# Altium schematic sheet P770010E05-Xx-SH-5_Connector.SchDoc(P770010E05-Xx-SH-5_Connector)
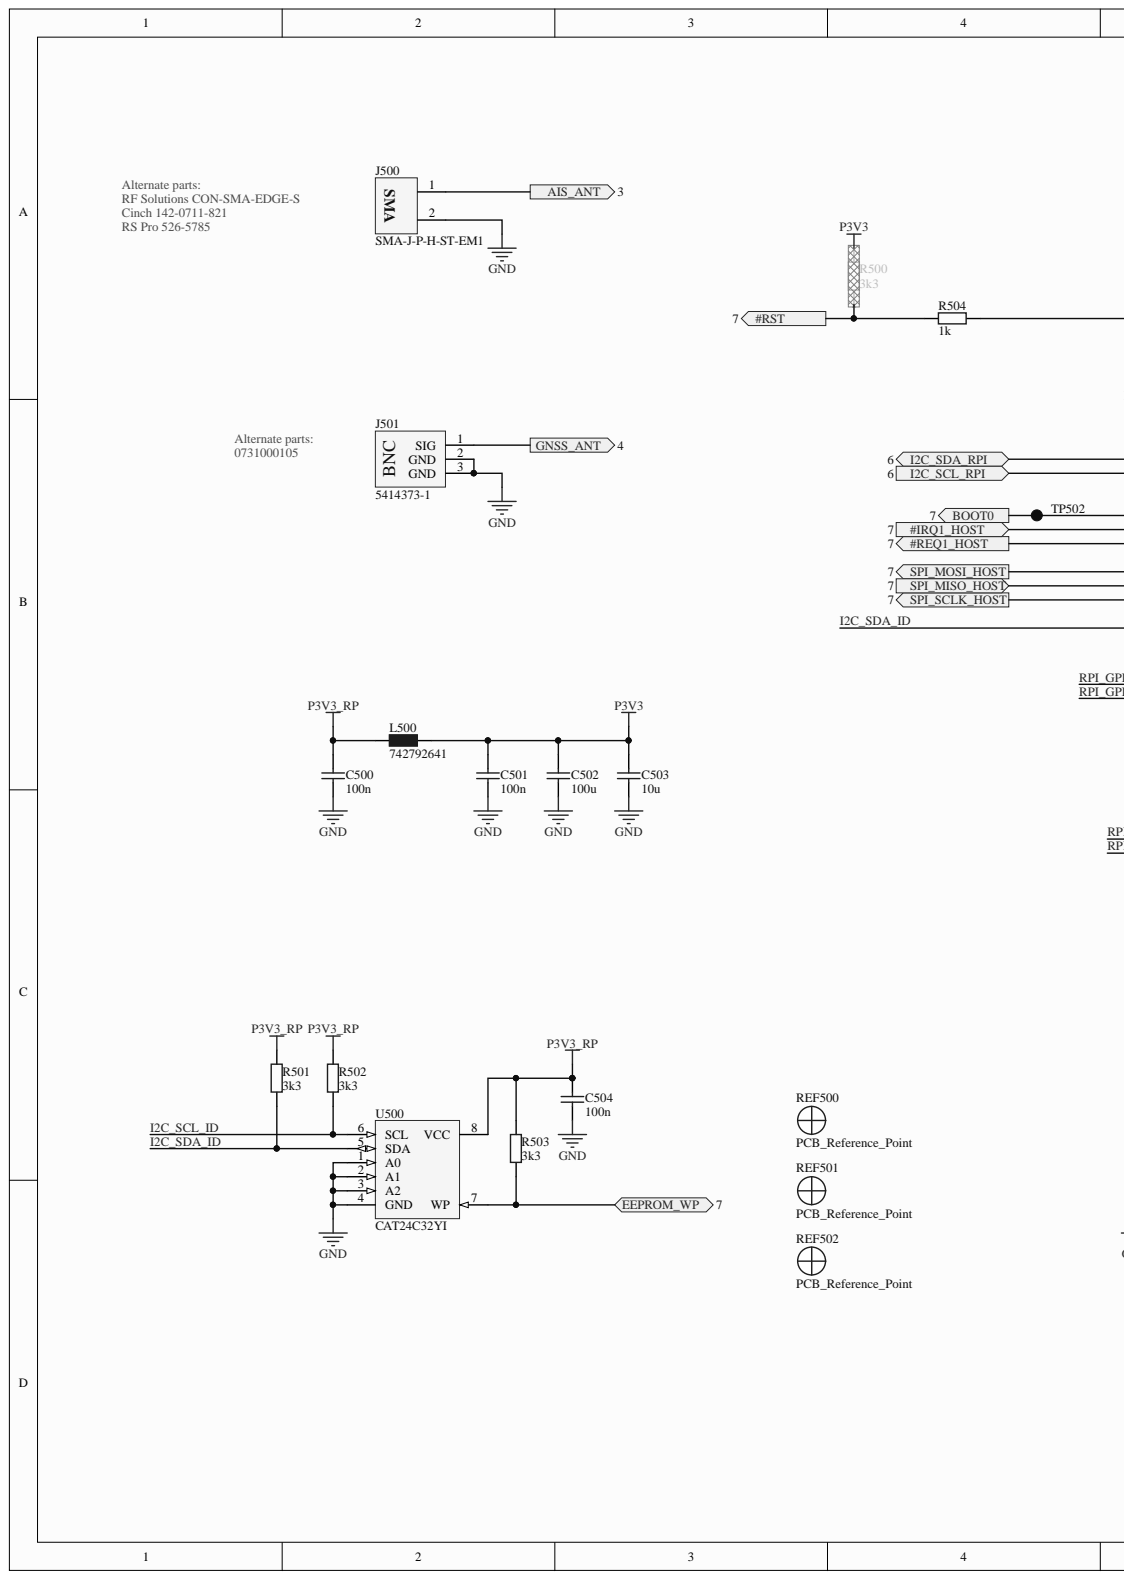
[diagram p0: P770010E05-Xx-SH-5_Connector.SchDoc, left]
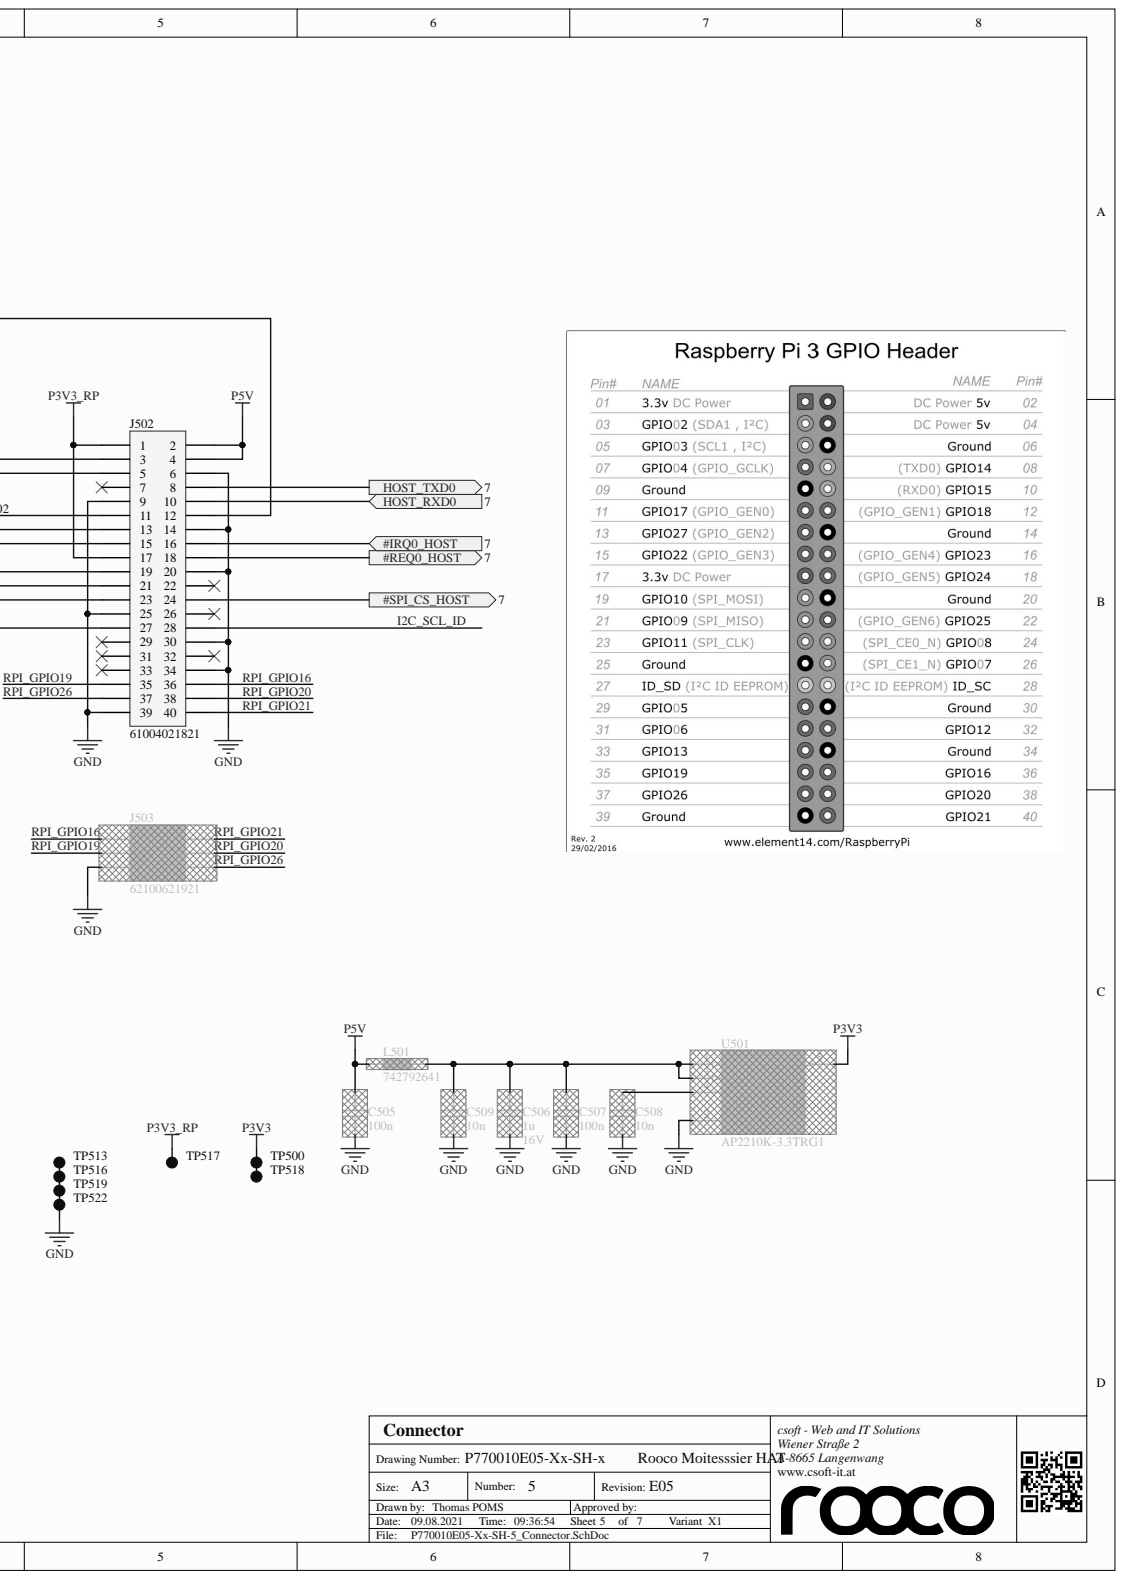
[diagram p1: P770010E05-Xx-SH-5_Connector.SchDoc, right]

## Parts
C500  100n  [C0402]
C501  100n  [C0402]
C502  100u  [C1206]
C503  10u  [C0805]
C504  100n  [C0402]
C505  100n  [C0402]
C506  1u  VoltageTypical: 16V  [C0603]
C507  100n  [C0402]
C508  10n  [C0402]
C509  10n  [C0402]
J500  SMA-J-P-H-ST-EM1  [SMA-J-P-X-ST-EM1_RECE-20279-001E-01]
J501  5414373-1  [5414373-1_RECE-20279-001E-01]
J502  61004021821  [61004021821_multi_compatible_with_beg]
J503  62100621921  [62100621921]
L500  742792641  [742792641]
L501  742792641  [742792641]
R500  3k3  [R0402]
R501  3k3  [R0402]
R502  3k3  [R0402]
R503  3k3  [R0402]
R504  1k  [R0402]
REF500  PCB_Reference_Point  [PCB_Reference_Point_1mm]  (no BOM)
REF501  PCB_Reference_Point  [PCB_Reference_Point_1mm]  (no BOM)
REF502  PCB_Reference_Point  [PCB_Reference_Point_1mm]  (no BOM)
TP500  testpoint  [TP_1.27x1.27]  (value not drawn)  (no BOM)
TP502  testpoint  [TP_1.27x1.27]  (value not drawn)  (no BOM)
TP513  testpoint  [TP_1.27x1.27]  (value not drawn)  (no BOM)
TP516  testpoint  [TP_1.27x1.27]  (value not drawn)  (no BOM)
TP517  testpoint  [TP_1.27x1.27]  (value not drawn)  (no BOM)
TP518  testpoint  [TP_1.27x1.27]  (value not drawn)  (no BOM)
TP519  testpoint  [TP_1.27x1.27]  (value not drawn)  (no BOM)
TP522  testpoint  [TP_1.27x1.27]  (value not drawn)  (no BOM)
U500  CAT24C32YI  [CAT24C32Yx_TSSOP-8]
U501  AP2210K-3.3TRG1  [AP2210Kx_SOT-23-5]

## Ports
#IRQ0_HOST, #IRQ1_HOST, #REQ0_HOST, #REQ1_HOST, #RST, #SPI_CS_HOST, AIS_ANT, BOOT0, EEPROM_WP, GNSS_ANT, HOST_RXD0, HOST_TXD0, I2C_SCL_RPI, I2C_SDA_RPI, SPI_MISO_HOST, SPI_MOSI_HOST, SPI_SCLK_HOST

## Nets
GND: C500.1 C501.1 C502.1 C503.1 C504.1 C505.1 C506.1 C507.1 C508.1 C509.1 J500.2 J501.2 J501.3 J502.6 J502.9 J502.14 J502.20 J502.25 J502.30 J502.34 J502.39 J503.2 TP513.1 TP516.1 TP519.1 TP522.1 U500.1(A0) U500.2(A1) U500.3(A2) U500.4(GND) U501.2(GND)
P3V3: C501.2 C502.2 C503.2 L500.1 R500.2 TP500.1 TP518.1 U501.5(OUT)
P3V3_RP: C500.2 C504.2 J502.1 J502.17 L500.2 R501.2 R502.2 R503.2 TP517.1 U500.8(VCC)
P5V: C505.2 J502.2 J502.4 L501.2
#IRQ0_HOST: J502.16 ; port #IRQ0_HOST
#IRQ1_HOST: J502.13 ; port #IRQ1_HOST
#REQ0_HOST: J502.18 ; port #REQ0_HOST
#REQ1_HOST: J502.15 ; port #REQ1_HOST
#RST: R500.1 R504.1 ; port #RST
#SPI_CS_HOST: J502.24 ; port #SPI_CS_HOST
AIS_ANT: J500.1 ; port AIS_ANT
BOOT0: J502.11 TP502.1 ; port BOOT0
EEPROM_WP: R503.1 U500.7(WP) ; port EEPROM_WP
GNSS_ANT: J501.1 ; port GNSS_ANT
HOST_RXD0: J502.10 ; port HOST_RXD0
HOST_TXD0: J502.8 ; port HOST_TXD0
I2C_SCL_ID: J502.28 R502.1 U500.6(SCL)
I2C_SCL_RPI: J502.5 ; port I2C_SCL_RPI
I2C_SDA_ID: J502.27 R501.1 U500.5(SDA)
I2C_SDA_RPI: J502.3 ; port I2C_SDA_RPI
RPI_GPIO16: J502.36 J503.6
RPI_GPIO19: J502.35 J503.4
RPI_GPIO20: J502.38 J503.3
RPI_GPIO21: J502.40 J503.5
RPI_GPIO26: J502.37 J503.1
SPI_MISO_HOST: J502.21 ; port SPI_MISO_HOST
SPI_MOSI_HOST: J502.19 ; port SPI_MOSI_HOST
SPI_SCLK_HOST: J502.23 ; port SPI_SCLK_HOST
NetC506_2: C506.2 C507.2 C509.2 L501.1 U501.1(IN) U501.3(EN)
NetC508_2: C508.2 U501.4(BYP)
NetJ502_12: J502.12 R504.2

Not connected: J502 7 22 26 29 31 32 33

## Library data of the parts
C500 C501 C504 C505 C507 = C1005X7R1H104K050BB: ceramic chip capacitor, 100n, 50V, X7R, 0402; Manufacturer: TDK; ID: 1; Obsolete: False; Published by: FT-TEC; RevisionHistory: [1.0]; ToleranceValue: +/-10%; Component Kind: Standard; ComponentLink1Description: Datasheet; ComponentLink1URL: http://www.tdk.com/pdf/TDKMLCCCapRange.pdf; ComponentType: Standard; Database Table Name: Capacitor; Dielectric: X7R; Library Name: CompanyDatabase.DbLib; Package: 0402; Part Number: C1005X7R1H104K050BB; Pin Count: 2; Published: 16.02.2012; Revision: 1.0; TemperatureMax: 125°C; TemperatureMin: -55°C; Value: 100n; VoltageTypical: 50V; Checked by: Thomas POMS; Drawn by: Thomas POMS
C502 = GRM31CR60J107ME39L: ceramic chip capacitor, 100u, 6.3V, X5R, 1206; Manufacturer: Murata; ID: 8; Obsolete: False; Published by: FT-TEC; RevisionHistory: [1.0]; ToleranceValue: +/-20%; Component Kind: Standard; ComponentLink1Description: Datasheet; ComponentLink1URL: http://psearch.murata.com/capacitor/product/GRM31CR60J107ME39%23.pdf; ComponentType: Standard; Database Table Name: Capacitor; Dielectric: X5R; Library Name: CompanyDatabase.DbLib; Package: 1206; Part Number: GRM31CR60J107ME39L; Pin Count: 2; Published: 24.02.2012; Revision: 1.0; TemperatureMax: 85°C; TemperatureMin: -55°C; Value: 100u; VoltageTypical: 6.3V; Checked by: Thomas POMS; Drawn by: Thomas POMS
C503 = C0805C106K4PAC7800: ceramic chip capacitor, 10u, 16V, X5R, 0805; Manufacturer: Kemet; ID: 6; Obsolete: False; Published by: FT-TEC; RevisionHistory: [1.0]; ToleranceValue: +/-10%; Component Kind: Standard; ComponentType: Standard; Database Table Name: Capacitor; Dielectric: X5R; Library Name: CompanyDatabase.DbLib; Package: 0805; Part Number: C0805C106K4PAC7800; Pin Count: 2; Published: 23.02.2012; Revision: 1.0; TemperatureMax: 85°C; TemperatureMin: -55°C; Value: 10u; VoltageTypical: 16V; Checked by: Thomas POMS; Drawn by: Thomas POMS
C506 = CC0603KRX7R7BB105: ceramic chip capacitor, 1u, 16V, X7R, 0603; Manufacturer: Yageo; ID: 2; Obsolete: False; Published by: FT-TEC; RevisionHistory: [1.0]; ToleranceValue: +/-10%; Component Kind: Standard; ComponentLink1Description: Datasheet; ComponentLink1URL: http://www.yageo.com/documents/recent/UPY-GPHC_X7R_6.3V-to-50V_8.pdf; ComponentType: Standard; Database Table Name: Capacitor; Dielectric: X7R; Library Name: CompanyDatabase.DbLib; Package: 0603; Part Number: CC0603KRX7R7BB105; Pin Count: 2; Published: 16.02.2012; Revision: 1.0; TemperatureMax: 125°C; TemperatureMin: -55°C; Value: 1u; Checked by: Thomas POMS; Drawn by: Thomas POMS
C508 C509 = GCM155R71H103KA55D: ceramic chip capacitor, 10nF, 50V, X7R, 0402; Manufacturer: Murata; ID: 13; Obsolete: False; Published by: FT-TEC; RevisionHistory: [1.0]; ToleranceValue: +/-10%; Component Kind: Standard; ComponentLink1Description: Datasheet; ComponentLink1URL: http://search.murata.co.jp/Ceramy/image/img/PDF/ENG/GCM155R71H103KA55.pdf; ComponentType: Standard; Database Table Name: Capacitor; Dielectric: X7R; Library Name: CompanyDatabase.DbLib; Package: 0402; Part Number: GCM155R71H103KA55D; Pin Count: 2; Published: 26.02.2012; Revision: 1.0; TemperatureMax: 125°C; TemperatureMin: -55°C; Value: 10n; VoltageTypical: 50V; Checked by: Thomas POMS; Drawn by: Thomas POMS
J500 = SMA-J-P-H-ST-EM1: SMA socket (female), DC - 6 GHz, edge mount; Manufacturer: Samtec; Database Table Name: Connector; ID: 37; Obsolete: False; Published by: FT-TEC; RevisionHistory: [1.0] Creation; Component Kind: Standard; ComponentLink1Description: Datasheet; ComponentLink1URL: http://www.samtec.com/documents/webfiles/cpdf/SMA-J-P-X-ST-EM1-MKT.pdf; ComponentType: Standard; Library Name: CompanyDatabase.DbLib; Part Number: SMA-J-P-H-ST-EM1; Pin Count: 5; Published: 20.08.2014; Revision: 1.0; TemperatureMax: 125°C; TemperatureMin: -65°C; Value: SMA-J-P-H-ST-EM1; Checked by: Thomas POMS; Drawn by: Thomas POMS
J501 = 5414373-1: BNC female jack, DC -4 GHz; Manufacturer: TE; Database Table Name: Connector; ID: 53; Obsolete: False; Published by: FT-TEC; RevisionHistory: [1.0] Creation; VoltageRating: 500V; Component Kind: Standard; ComponentLink1Description: Documentation; ComponentLink1URL: http://www.te.com/usa-en/product-5414373-1.html#pdp-docs-features; ComponentType: Standard; Library Name: CompanyDatabase.DbLib; Part Number: 5414373-1; Pin Count: 3; Published: 26.10.2017; Revision: 1.0; TemperatureMax: 85°C; TemperatureMin: -55°C; Value: 5414373-1; Checked by: Thomas POMS; Drawn by: Thomas POMS
J502 = 61004021821: socket, 2.54mm, 20x2 pin, vertical, surface mount; Manufacturer: Würth; CurrentRating: 3.0A; Database Table Name: Header; ID: 33; Obsolete: False; Published by: FT-TEC; RevisionHistory: [1.0] Creation; VoltageRating: 250V; Component Kind: Standard; ComponentLink1Description: Datasheet; ComponentLink1URL: http://katalog.we-online.de/em/datasheet/6100xx21821.pdf; ComponentType: Standard; Library Name: CompanyDatabase.DbLib; Part Number: 61004021821; PinClearance: 2.54mm; Pin Count: 40; Published: 19.10.2017; Revision: 1.0; TemperatureMax: 105°C; TemperatureMin: -40°C; Value: 61004021821; Checked by: Thomas POMS; Drawn by: Thomas POMS
J503 = 62100621921: header, 2mm, 2x3pin, vertical; Manufacturer: Würth; CurrentRating: 2.0A; ID: 23; Obsolete: False; Published by: FT-TEC; RevisionHistory: [1.0] Creation; Component Kind: Standard; ComponentLink1Description: Datasheet; ComponentLink1URL: http://katalog.we-online.de/em/datasheet/6210xx21921.pdf; ComponentType: Standard; Database Table Name: Header; Library Name: CompanyDatabase.DbLib; Part Number: 62100621921; PinClearance: 2mm; Pin Count: 6; Published: 23.12.2015; Revision: 1.0; TemperatureMax: 125°C; TemperatureMin: -40°C; Value: 62100621921; Checked by: Thomas POMS; Drawn by: Thomas POMS
L500 L501 = 742792641: EMI suppression ferrite bead, 2A, 0.15R, Z=300R@100MHz; Manufacturer: Würth; Database Table Name: Inductor; ID: 13; Obsolete: False; Published by: FT-TEC; RevisionHistory: [1.0] Creation; Component Kind: Standard; ComponentLink1Description: Datasheet; ComponentLink1URL: http://katalog.we-online.com/pbs/datasheet/742792641.pdf; ComponentType: Standard; CurrentRating: 2A; Impedance: 300R@100MHz; Library Name: CompanyDatabase.DbLib; Package: 0603; Part Number: 742792641; Pin Count: 2; Published: 20.02.2013; ResistanceDCmax: 0.15R; Revision: 1.0; TemperatureMax: 125°C; TemperatureMin: -55°C; Checked by: Thomas POMS; Drawn by: Thomas POMS
R500-R503 = CRCW04023K30FKED: thick film resistor, 3.3k, 1%, 100ppm,  0402; Manufacturer: Vishay; ID: 20; Obsolete: False; PowerRating: 63mW; Published by: FT-TEC; RevisionHistory: [1.0] Creation; VoltageRating: 50V; Component Kind: Standard; ComponentLink1Description: Datasheet; ComponentLink1URL: http://www.vishay.com/docs/20035/dcrcwe3.pdf; ComponentType: Standard; Database Table Name: Resistor; Library Name: CompanyDatabase.DbLib; Package: 0402; Part Number: CRCW04023K30FKED; Pin Count: 2; Published: 27.02.2012; Revision: 1.0; TemperatureCoefficient: 100ppm; TemperatureMax: 155°C; TemperatureMin: -55°C; ToleranceValue: 1%; Value: 3k3; Checked by: Thomas POMS; Drawn by: Thomas Poms
R504 = CRCW04021K00FKED: thick film resistor, 1k, 1%, 100ppm, 0402; Manufacturer: Vishay; ID: 4; Obsolete: False; PowerRating: 63mW; Published by: FT-TEC; RevisionHistory: [1.0] Creation; VoltageRating: 50V; Component Kind: Standard; ComponentLink1Description: Datasheet; ComponentLink1URL: http://www.vishay.com/docs/20035/dcrcwe3.pdf; ComponentType: Standard; Database Table Name: Resistor; Library Name: CompanyDatabase.DbLib; Package: 0402; Part Number: CRCW04021K00FKED; Pin Count: 2; Published: 16.02.2012; Revision: 1.0; TemperatureCoefficient: 100ppm; TemperatureMax: 155°C; TemperatureMin: -55°C; ToleranceValue: 1%; Value: 1k; Checked by: Thomas POMS; Drawn by: Thomas Poms
REF500-REF502 = PCB_Reference_Point_1mm: 1 mm PCB reference point; ID: 2; Obsolete: False; Published by: FT-TEC; RevisionHistory: [1.0] Creation; ComponentType: Standard (No BOM); Database Table Name: PCB_Reference_Point; Library Name: CompanyDatabase.DbLib; Part Number: PCB_Reference_Point_1mm; Published: 25.03.2014; Revision: 1.0; Value: PCB_Reference_Point_1mm; Checked by: Thomas POMS; Drawn by: Thomas POMS
TP500 TP502 TP513 TP516-TP519 TP522 = TP_1.27x1.27: Testpoint 1.27mm x 1.27mm; Manufacturer: none; ID: 1; Obsolete: False; Published by: FT-TEC; RevisionHistory: [1.0] Creation; ComponentType: Standard; Database Table Name: Testpoint; Library Name: CompanyDatabase.DbLib; Part Number: TP_1.27x1.27; Revision: 1.0; Value: TP_1.27x1.27; Checked by: Thomas POMS; Drawn by: Thomas POMS
U500 = CAT24C32YI: 32kBit I2C EEPROM; Manufacturer: ON Semiconductor; Database Table Name: Memory; ID: 9; Obsolete: False; Published by: FT-TEC; RevisionHistory: [1.0] Creation; VoltageMax: 5.0V; VoltageMin: 1.7V; VoltageTypical: 3.3V; Component Kind: Standard; ComponentLink1Description: Datasheet; ComponentLink1URL: http://www.onsemi.com/pub/Collateral/CAT24C32-D.PDF; ComponentType: Standard; Library Name: CompanyDatabase.DbLib; MemorySize: 32kBit; MemoryType: EEPROM; Package: TSSOP-8; Part Number: CAT24C32YI; Pin Count: 8; Published: 29.10.2017; Revision: 1.0; TemperatureMax: 85°C; TemperatureMin: -40°C; Value: CAT24C32YI; Checked by: Thomas POMS; Drawn by: Thomas POMS
U501 = AP2210K-3.3TRG1: 300mA Low Noise LDO, 3.3V; Manufacturer: BCD; Database Table Name: Voltage_Regulator; ID: 11; InputVoltageMax: 13.2V; InputVoltageMin: 3.8V; Obsolete: False; Published by: FT-TEC; RevisionHistory: [1.0] Creation; Tolerance: +/- 1%; Component Kind: Standard; ComponentLink1Description: Datasheet; ComponentLink1URL: http://www.diodes.com/datasheets/AP2210.pdf; ComponentType: Standard; CurrentRating: 300mA; Library Name: CompanyDatabase.DbLib; OutputVoltageMax: 3.3V; OutputVoltageMin: 3.3V; Package: SOT-23-5; Part Number: AP2210K-3.3TRG1; Pin Count: 5; Published: 27.08.2014; Revision: 1.0; TemperatureMax: 125°C; TemperatureMin: -40°C; Value: AP2210K-3.3TRG1; Checked by: Thomas POMS; Drawn by: Thomas POMS
